# Altium schematic sheet 2_MISC.SchDoc(2_MISC)
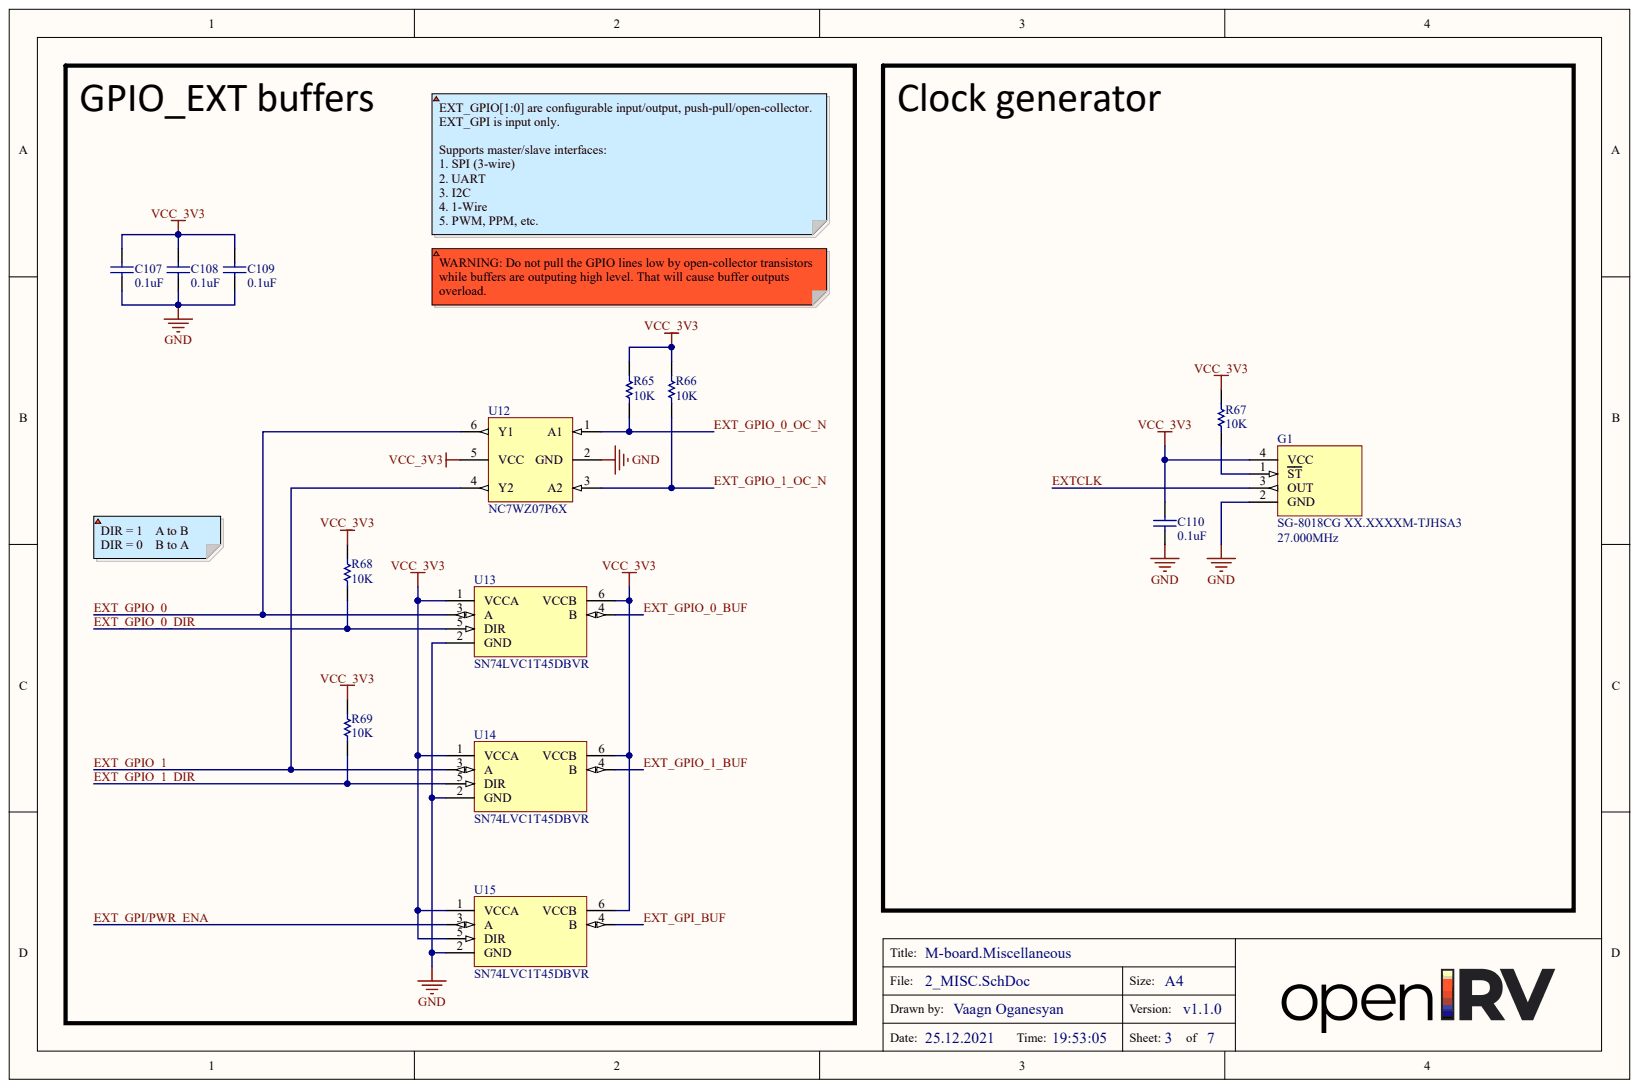
[diagram p0: 2_MISC.SchDoc]

## Parts
C107  0.1uF  [C0402[1005]]
C108  0.1uF  [C0402[1005]]
C109  0.1uF  [C0402[1005]]
C110  0.1uF  [C0402[1005]]
G1  SG-8018CG XX.XXXXM-TJHSA3  Frequency: 27.000MHz  [SG-8018CG XX.XXXXM-TJHSA3]
R65  10K  [R0402[1005]]
R66  10K  [R0402[1005]]
R67  10K  [R0402[1005]]
R68  10K  [R0402[1005]]
R69  10K  [R0402[1005]]
U12  NC7WZ07P6X  [NC7WZ07P6X]
U13  SN74LVC1T45DBVR  [SN74LVC1T45DBVR]
U14  SN74LVC1T45DBVR  [SN74LVC1T45DBVR]
U15  SN74LVC1T45DBVR  [SN74LVC1T45DBVR]

## Nets
GND: C107.2 C108.2 C109.2 C110.2 G1.2(GND) U12.2(GND) U13.2(GND) U14.2(GND) U15.2(GND)
VCC_3V3: C107.1 C108.1 C109.1 C110.1 G1.4(VCC) R65.2 R66.2 R67.2 R68.2 R69.2 U12.5(VCC) U13.1(VCCA) U13.6(VCCB) U14.1(VCCA) U14.6(VCCB) U15.1(VCCA) U15.5(DIR) U15.6(VCCB)
EXT_GPI/PWR_ENA: U15.3(A)
EXT_GPI_BUF: U15.4(B)
EXT_GPIO_0: U12.6(Y1) U13.3(A)
EXT_GPIO_0_BUF: U13.4(B)
EXT_GPIO_0_DIR: R68.1 U13.5(DIR)
EXT_GPIO_0_OC_N: R65.1 U12.1(A1)
EXT_GPIO_1: U12.4(Y2) U14.3(A)
EXT_GPIO_1_BUF: U14.4(B)
EXT_GPIO_1_DIR: R69.1 U14.5(DIR)
EXT_GPIO_1_OC_N: R66.1 U12.3(A2)
EXTCLK: G1.3(OUT)
NetG1_1: G1.1(ST) R67.1

## Library data of the parts
C107-C110 = CAP: Dielectric: X7R; Tolerance: 10%; Voltage: 50V; Component Kind: Standard; Library Name: general.IntLib; Mounting: SMD; Value: 0.1uF
G1 = SG-8018CG XX.XXXXM-TJHSA3: Oscillator; Component Kind: Standard; Library Name: general.IntLib; ManufacturerPartNumber: SG-8018CG XX.XXXXM-TJHSA3
R65-R69 = RES: Power: 0.06W; Tolerance: 5%; Component Kind: Standard; Library Name: general.IntLib; Mounting: SMD; Value: 10K
U12 = NC7WZ07P6X: Dual open-drain buffer; Component Kind: Standard; Library Name: general.IntLib
U13-U15 = SN74LVC1T45DBVR: Single-Bit Dual-Supply Bus Transceiver; Component Kind: Standard; Library Name: general.IntLib
